# Altium schematic sheet ГРЛМ.468389.005 Э3.SchDoc
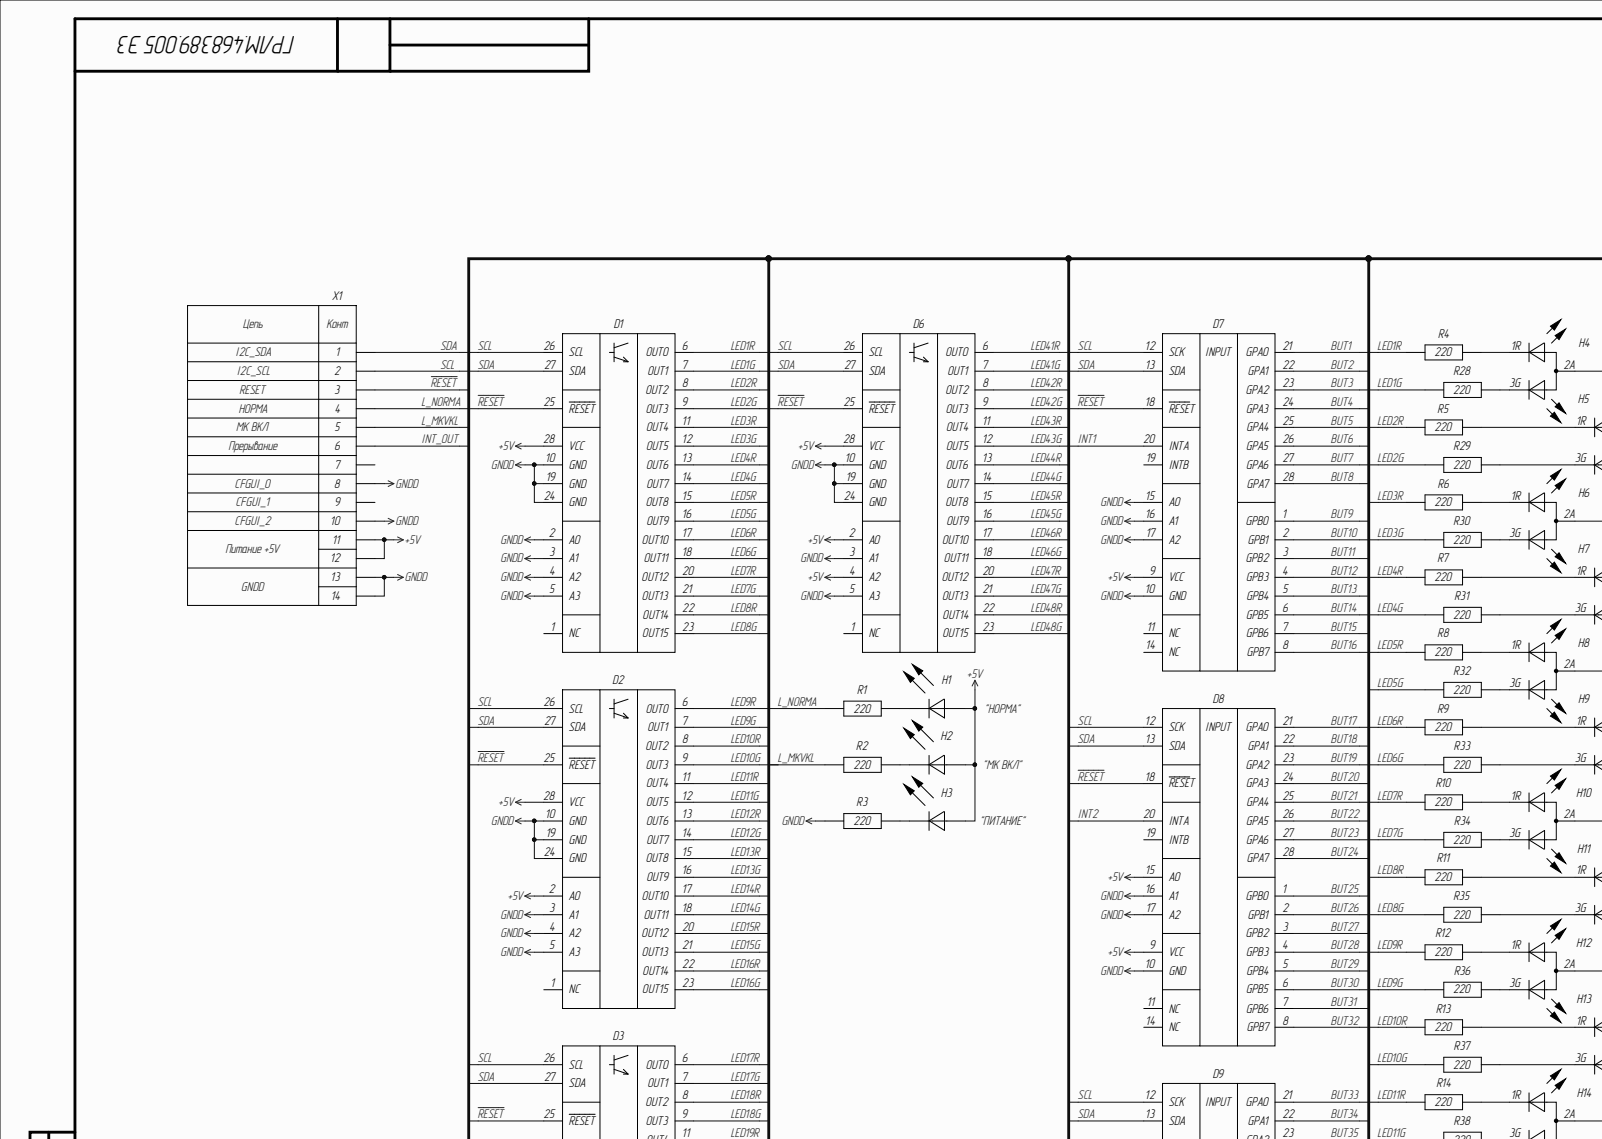
[diagram p0: ГРЛМ.468389.005 Э3.SchDoc, top left]
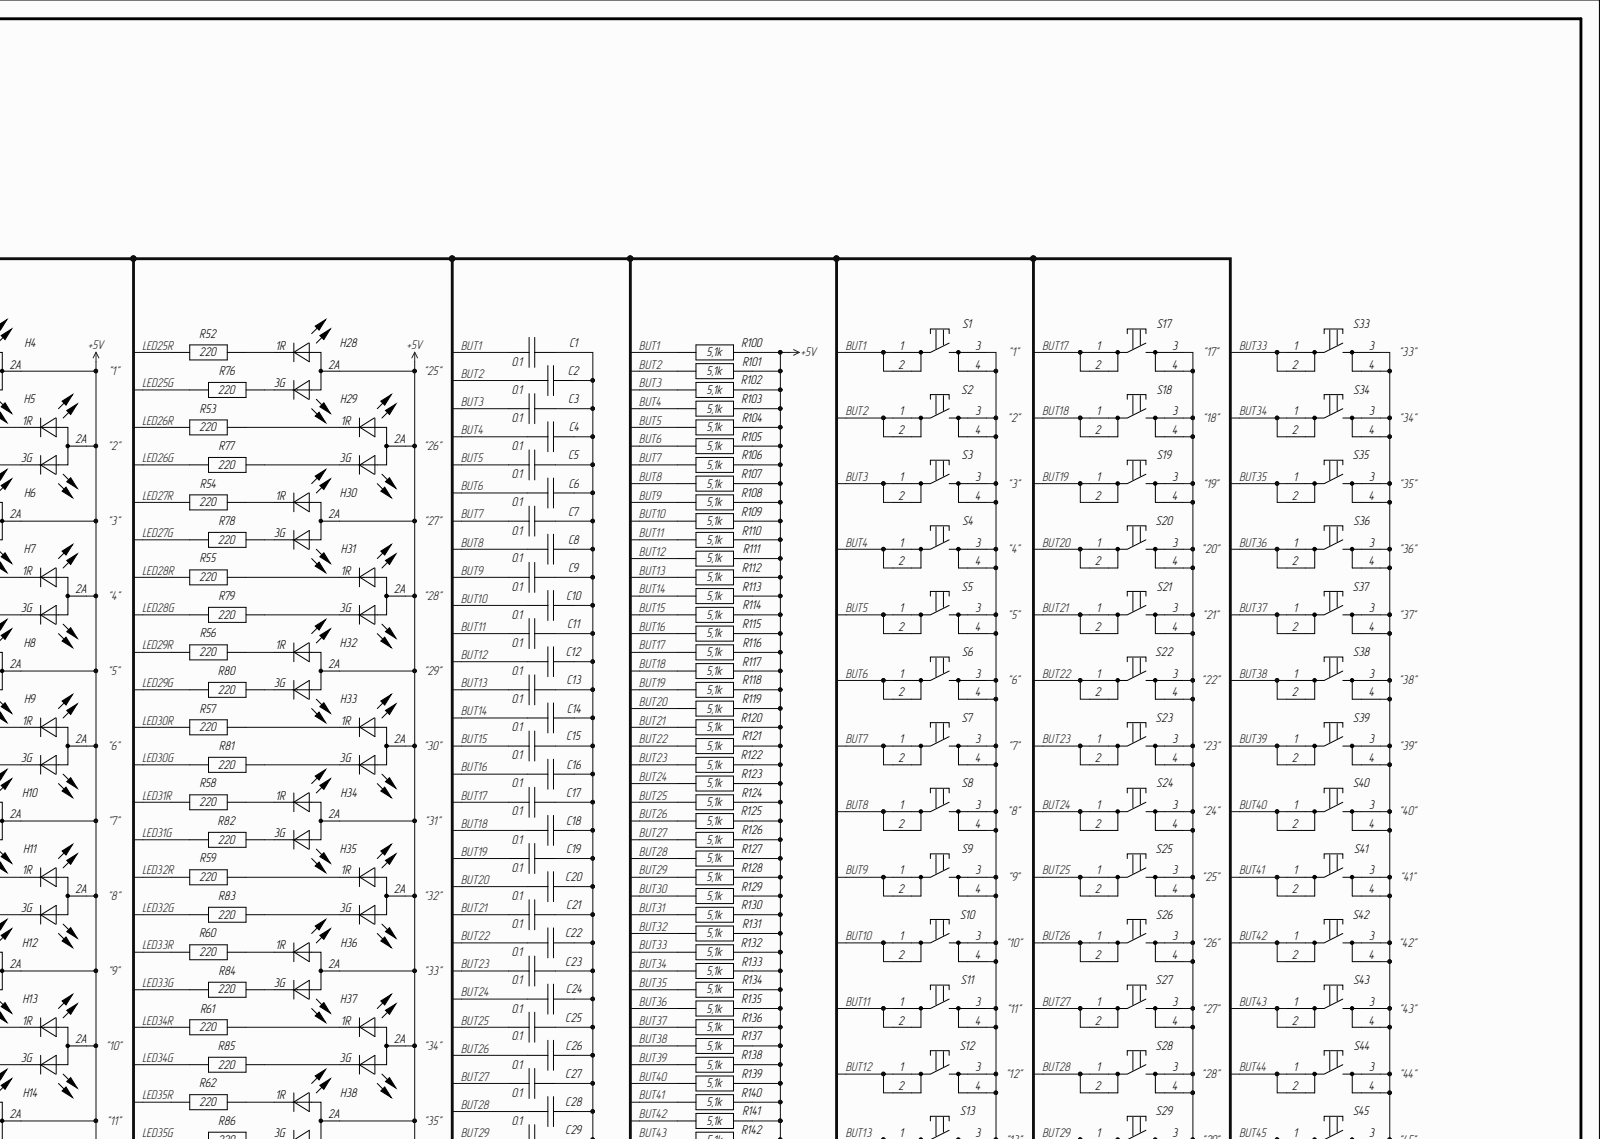
[diagram p1: ГРЛМ.468389.005 Э3.SchDoc, top right]
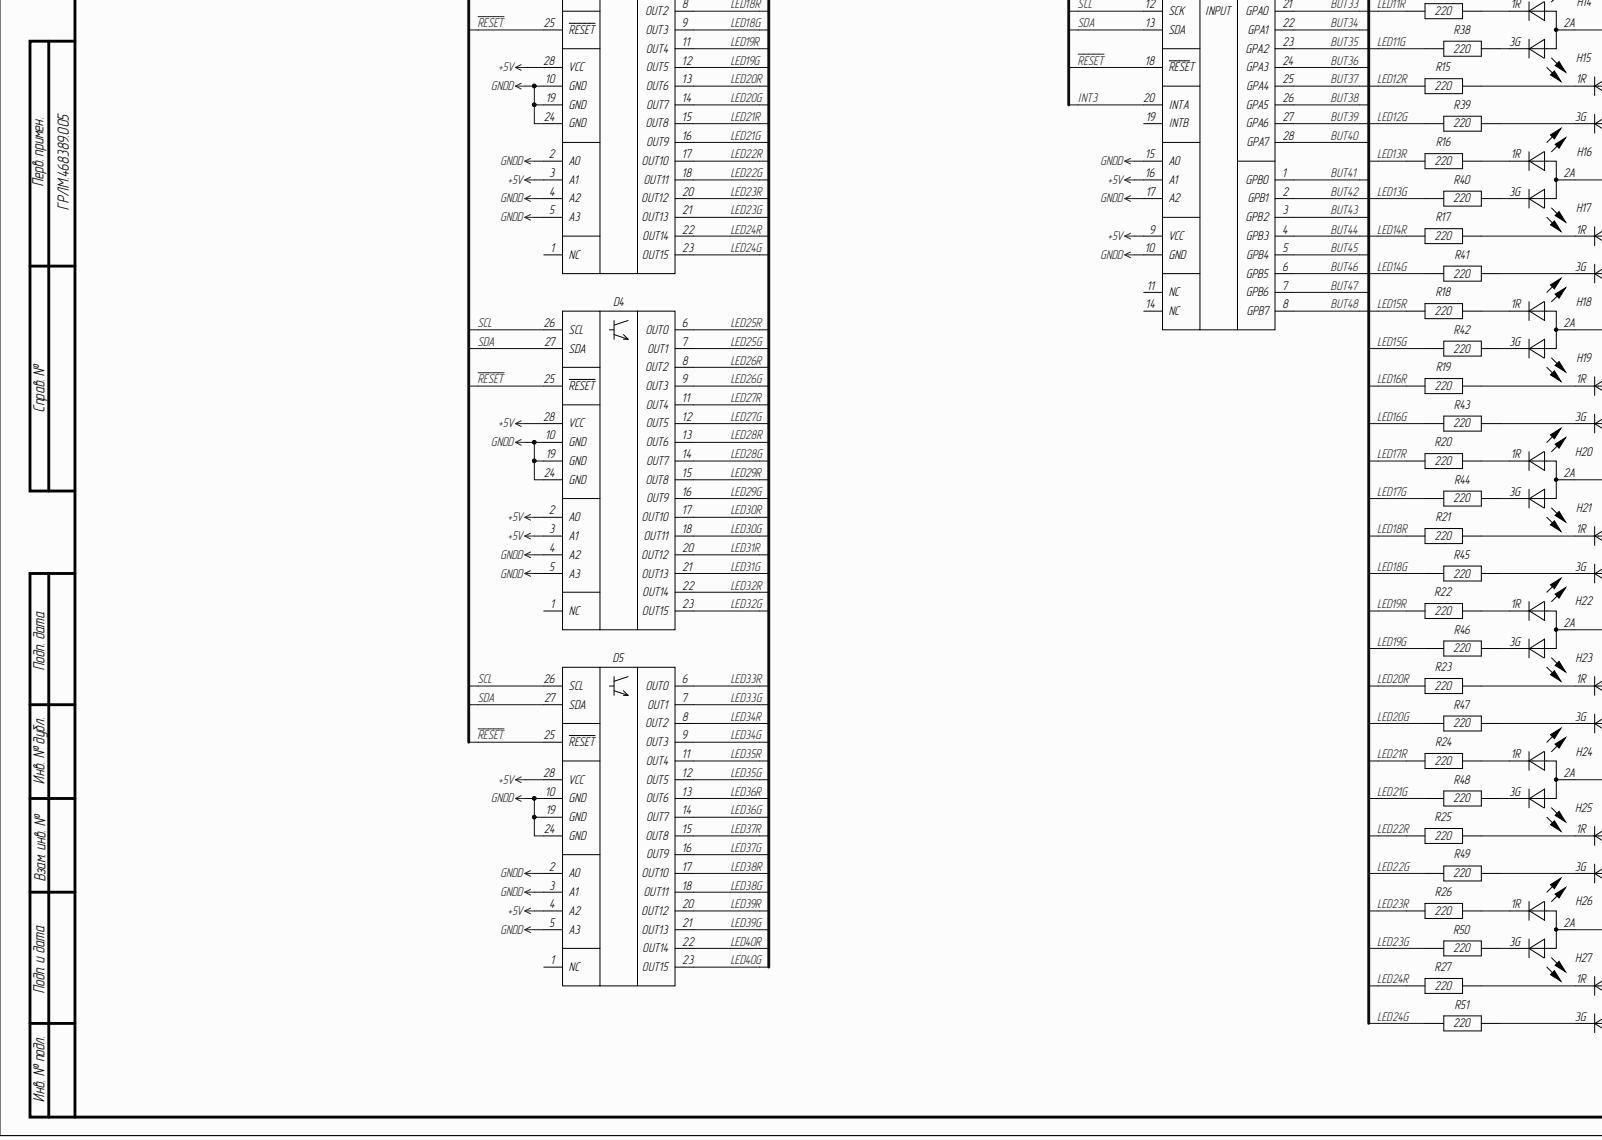
[diagram p2: ГРЛМ.468389.005 Э3.SchDoc, bottom left]
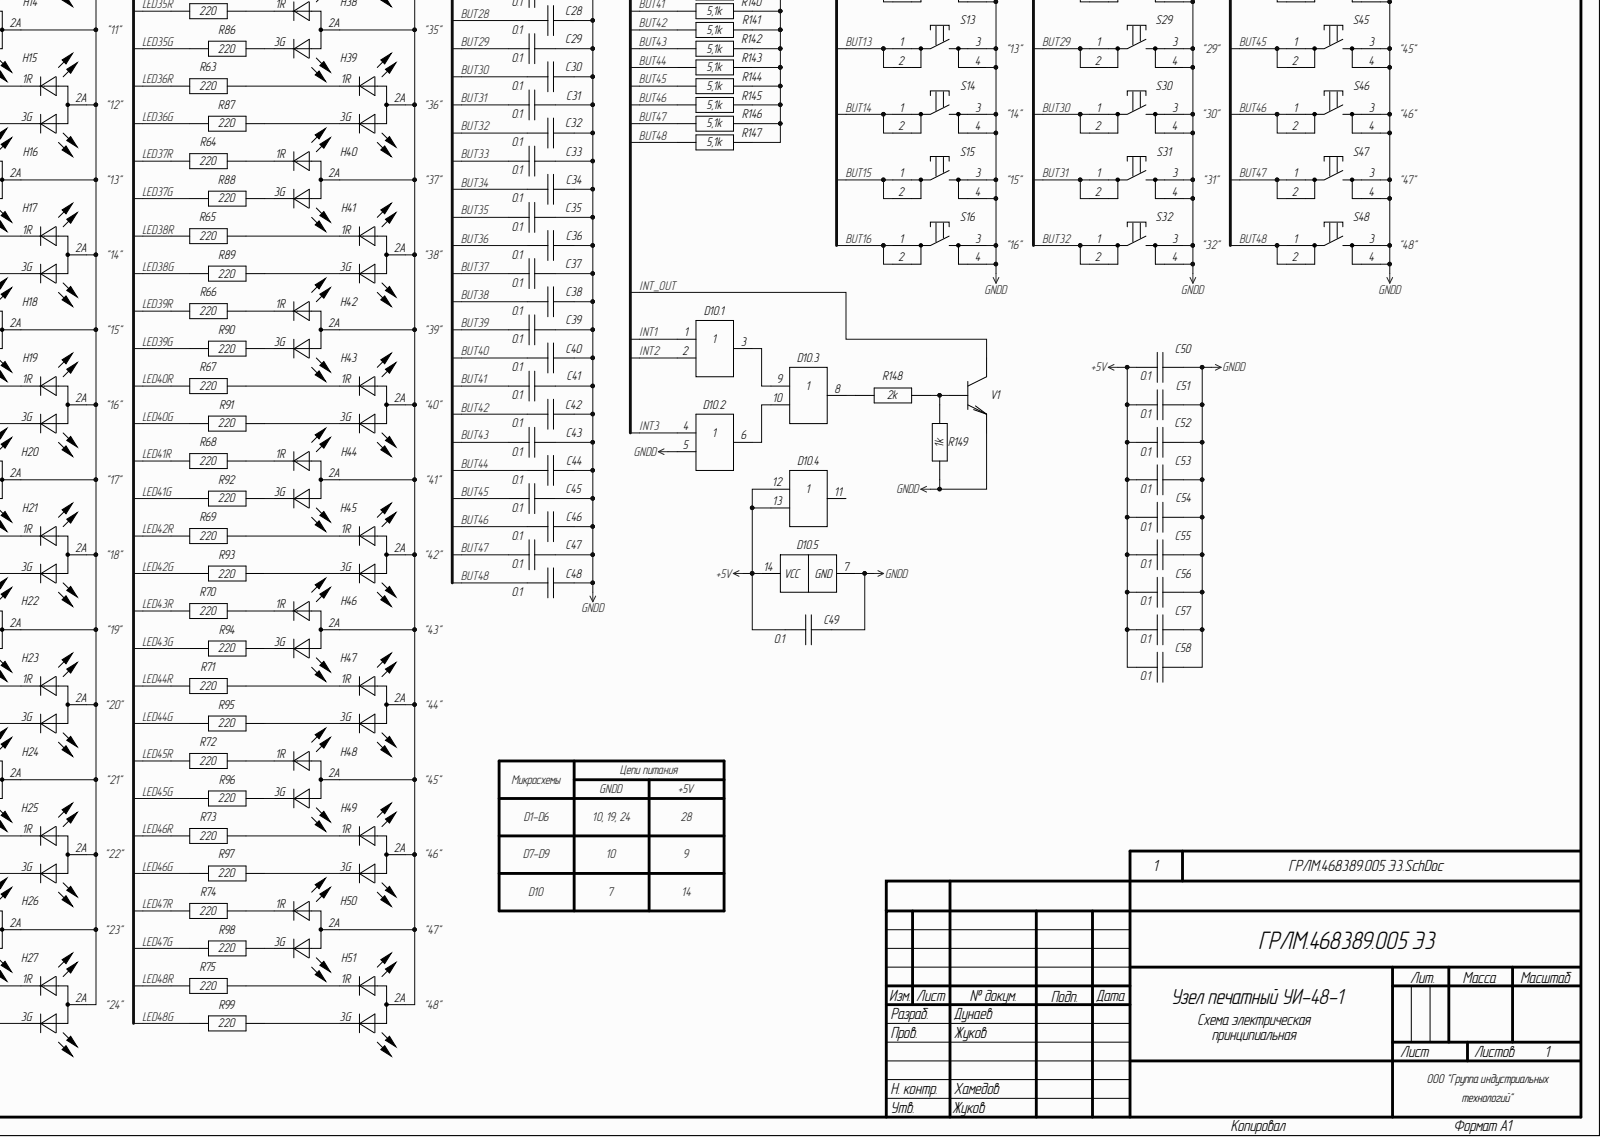
[diagram p3: ГРЛМ.468389.005 Э3.SchDoc, bottom right]

## Parts
C1  value 0.1  [CAP0805]  (hidden comment: CERCAP_0805)
C2  value 0.1  [CAP0805]  (hidden comment: CERCAP_0805)
C3  value 0.1  [CAP0805]  (hidden comment: CERCAP_0805)
C4  value 0.1  [CAP0805]  (hidden comment: CERCAP_0805)
C5  value 0.1  [CAP0805]  (hidden comment: CERCAP_0805)
C6  value 0.1  [CAP0805]  (hidden comment: CERCAP_0805)
C7  value 0.1  [CAP0805]  (hidden comment: CERCAP_0805)
C8  value 0.1  [CAP0805]  (hidden comment: CERCAP_0805)
C9  value 0.1  [CAP0805]  (hidden comment: CERCAP_0805)
C10  value 0.1  [CAP0805]  (hidden comment: CERCAP_0805)
C11  value 0.1  [CAP0805]  (hidden comment: CERCAP_0805)
C12  value 0.1  [CAP0805]  (hidden comment: CERCAP_0805)
C13  value 0.1  [CAP0805]  (hidden comment: CERCAP_0805)
C14  value 0.1  [CAP0805]  (hidden comment: CERCAP_0805)
C15  value 0.1  [CAP0805]  (hidden comment: CERCAP_0805)
C16  value 0.1  [CAP0805]  (hidden comment: CERCAP_0805)
C17  value 0.1  [CAP0805]  (hidden comment: CERCAP_0805)
C18  value 0.1  [CAP0805]  (hidden comment: CERCAP_0805)
C19  value 0.1  [CAP0805]  (hidden comment: CERCAP_0805)
C20  CERCAP_0805  [CAP0805]  (value not drawn)
C21  value 0.1  [CAP0805]  (hidden comment: CERCAP_0805)
C22  value 0.1  [CAP0805]  (hidden comment: CERCAP_0805)
C23  value 0.1  [CAP0805]  (hidden comment: CERCAP_0805)
C24  value 0.1  [CAP0805]  (hidden comment: CERCAP_0805)
C25  value 0.1  [CAP0805]  (hidden comment: CERCAP_0805)
C26  value 0.1  [CAP0805]  (hidden comment: CERCAP_0805)
C27  value 0.1  [CAP0805]  (hidden comment: CERCAP_0805)
C28  value 0.1  [CAP0805]  (hidden comment: CERCAP_0805)
C29  value 0.1  [CAP0805]  (hidden comment: CERCAP_0805)
C30  value 0.1  [CAP0805]  (hidden comment: CERCAP_0805)
C31  value 0.1  [CAP0805]  (hidden comment: CERCAP_0805)
C32  value 0.1  [CAP0805]  (hidden comment: CERCAP_0805)
C33  value 0.1  [CAP0805]  (hidden comment: CERCAP_0805)
C34  value 0.1  [CAP0805]  (hidden comment: CERCAP_0805)
C35  value 0.1  [CAP0805]  (hidden comment: CERCAP_0805)
C36  value 0.1  [CAP0805]  (hidden comment: CERCAP_0805)
C37  value 0.1  [CAP0805]  (hidden comment: CERCAP_0805)
C38  value 0.1  [CAP0805]  (hidden comment: CERCAP_0805)
C39  value 0.1  [CAP0805]  (hidden comment: CERCAP_0805)
C40  value 0.1  [CAP0805]  (hidden comment: CERCAP_0805)
C41  value 0.1  [CAP0805]  (hidden comment: CERCAP_0805)
C42  value 0.1  [CAP0805]  (hidden comment: CERCAP_0805)
C43  value 0.1  [CAP0805]  (hidden comment: CERCAP_0805)
C44  value 0.1  [CAP0805]  (hidden comment: CERCAP_0805)
C45  value 0.1  [CAP0805]  (hidden comment: CERCAP_0805)
C46  value 0.1  [CAP0805]  (hidden comment: CERCAP_0805)
C47  value 0.1  [CAP0805]  (hidden comment: CERCAP_0805)
C48  value 0.1  [CAP0805]  (hidden comment: CERCAP_0805)
C49  value 0.1  [CAP0805]  (hidden comment: CERCAP_0805)
C50  value 0.1  [CAP0805]  (hidden comment: CERCAP_0805)
C51  value 0.1  [CAP0805]  (hidden comment: CERCAP_0805)
C52  value 0.1  [CAP0805]  (hidden comment: CERCAP_0805)
C53  value 0.1  [CAP0805]  (hidden comment: CERCAP_0805)
C54  value 0.1  [CAP0805]  (hidden comment: CERCAP_0805)
C55  value 0.1  [CAP0805]  (hidden comment: CERCAP_0805)
C56  value 0.1  [CAP0805]  (hidden comment: CERCAP_0805)
C57  value 0.1  [CAP0805]  (hidden comment: CERCAP_0805)
C58  value 0.1  [CAP0805]  (hidden comment: CERCAP_0805)
D1  TLC59116F  [TLC59116F]  (value not drawn)
D2  TLC59116F  [TLC59116F]  (value not drawn)
D3  TLC59116F  [TLC59116F]  (value not drawn)
D4  TLC59116F  [TLC59116F]  (value not drawn)
D5  TLC59116F  [TLC59116F]  (value not drawn)
D6  TLC59116F  [TLC59116F]  (value not drawn)
D7  MCP23017  [MCP23017]  (value not drawn)
D8  MCP23017  [MCP23017]  (value not drawn)
D9  MCP23017  [MCP23017]  (value not drawn)
D10  ЭКФ1533ЛЛ1  [ЭКФ1533ЛЛ1]  (value not drawn)  (parts .1,.2,.3,.4,.5)
H1  TLHG4400  [TLHG4400]  (value not drawn)
H2  TLHR4400  [TLHR4400]  (value not drawn)
H3  TLHG4400  [TLHG4400]  (value not drawn)
H4  SSL-LX3059IGW-CA  [SSL-LX3059IGW-CA]  (value not drawn)
H5  SSL-LX3059IGW-CA  [SSL-LX3059IGW-CA]  (value not drawn)
H6  SSL-LX3059IGW-CA  [SSL-LX3059IGW-CA]  (value not drawn)
H7  SSL-LX3059IGW-CA  [SSL-LX3059IGW-CA]  (value not drawn)
H8  SSL-LX3059IGW-CA  [SSL-LX3059IGW-CA]  (value not drawn)
H9  SSL-LX3059IGW-CA  [SSL-LX3059IGW-CA]  (value not drawn)
H10  SSL-LX3059IGW-CA  [SSL-LX3059IGW-CA]  (value not drawn)
H11  SSL-LX3059IGW-CA  [SSL-LX3059IGW-CA]  (value not drawn)
H12  SSL-LX3059IGW-CA  [SSL-LX3059IGW-CA]  (value not drawn)
H13  SSL-LX3059IGW-CA  [SSL-LX3059IGW-CA]  (value not drawn)
H14  SSL-LX3059IGW-CA  [SSL-LX3059IGW-CA]  (value not drawn)
H15  SSL-LX3059IGW-CA  [SSL-LX3059IGW-CA]  (value not drawn)
H16  SSL-LX3059IGW-CA  [SSL-LX3059IGW-CA]  (value not drawn)
H17  SSL-LX3059IGW-CA  [SSL-LX3059IGW-CA]  (value not drawn)
H18  SSL-LX3059IGW-CA  [SSL-LX3059IGW-CA]  (value not drawn)
H19  SSL-LX3059IGW-CA  [SSL-LX3059IGW-CA]  (value not drawn)
H20  SSL-LX3059IGW-CA  [SSL-LX3059IGW-CA]  (value not drawn)
H21  SSL-LX3059IGW-CA  [SSL-LX3059IGW-CA]  (value not drawn)
H22  SSL-LX3059IGW-CA  [SSL-LX3059IGW-CA]  (value not drawn)
H23  SSL-LX3059IGW-CA  [SSL-LX3059IGW-CA]  (value not drawn)
H24  SSL-LX3059IGW-CA  [SSL-LX3059IGW-CA]  (value not drawn)
H25  SSL-LX3059IGW-CA  [SSL-LX3059IGW-CA]  (value not drawn)
H26  SSL-LX3059IGW-CA  [SSL-LX3059IGW-CA]  (value not drawn)
H27  SSL-LX3059IGW-CA  [SSL-LX3059IGW-CA]  (value not drawn)
H28  SSL-LX3059IGW-CA  [SSL-LX3059IGW-CA]  (value not drawn)
H29  SSL-LX3059IGW-CA  [SSL-LX3059IGW-CA]  (value not drawn)
H30  SSL-LX3059IGW-CA  [SSL-LX3059IGW-CA]  (value not drawn)
H31  SSL-LX3059IGW-CA  [SSL-LX3059IGW-CA]  (value not drawn)
H32  SSL-LX3059IGW-CA  [SSL-LX3059IGW-CA]  (value not drawn)
H33  SSL-LX3059IGW-CA  [SSL-LX3059IGW-CA]  (value not drawn)
H34  SSL-LX3059IGW-CA  [SSL-LX3059IGW-CA]  (value not drawn)
H35  SSL-LX3059IGW-CA  [SSL-LX3059IGW-CA]  (value not drawn)
H36  SSL-LX3059IGW-CA  [SSL-LX3059IGW-CA]  (value not drawn)
H37  SSL-LX3059IGW-CA  [SSL-LX3059IGW-CA]  (value not drawn)
H38  SSL-LX3059IGW-CA  [SSL-LX3059IGW-CA]  (value not drawn)
H39  SSL-LX3059IGW-CA  [SSL-LX3059IGW-CA]  (value not drawn)
H40  SSL-LX3059IGW-CA  [SSL-LX3059IGW-CA]  (value not drawn)
H41  SSL-LX3059IGW-CA  [SSL-LX3059IGW-CA]  (value not drawn)
H42  SSL-LX3059IGW-CA  [SSL-LX3059IGW-CA]  (value not drawn)
H43  SSL-LX3059IGW-CA  [SSL-LX3059IGW-CA]  (value not drawn)
H44  SSL-LX3059IGW-CA  [SSL-LX3059IGW-CA]  (value not drawn)
H45  SSL-LX3059IGW-CA  [SSL-LX3059IGW-CA]  (value not drawn)
H46  SSL-LX3059IGW-CA  [SSL-LX3059IGW-CA]  (value not drawn)
H47  SSL-LX3059IGW-CA  [SSL-LX3059IGW-CA]  (value not drawn)
H48  SSL-LX3059IGW-CA  [SSL-LX3059IGW-CA]  (value not drawn)
H49  SSL-LX3059IGW-CA  [SSL-LX3059IGW-CA]  (value not drawn)
H50  SSL-LX3059IGW-CA  [SSL-LX3059IGW-CA]  (value not drawn)
H51  SSL-LX3059IGW-CA  [SSL-LX3059IGW-CA]  (value not drawn)
R1  value 220  [RES0805]  (hidden comment: RES_0805)
R2  value 220  [RES0805]  (hidden comment: RES_0805)
R3  value 220  [RES0805]  (hidden comment: RES_0805)
R4  value 220  [RES0805]  (hidden comment: RES_0805)
R5  value 220  [RES0805]  (hidden comment: RES_0805)
R6  value 220  [RES0805]  (hidden comment: RES_0805)
R7  value 220  [RES0805]  (hidden comment: RES_0805)
R8  value 220  [RES0805]  (hidden comment: RES_0805)
R9  value 220  [RES0805]  (hidden comment: RES_0805)
R10  value 220  [RES0805]  (hidden comment: RES_0805)
R11  value 220  [RES0805]  (hidden comment: RES_0805)
R12  value 220  [RES0805]  (hidden comment: RES_0805)
R13  value 220  [RES0805]  (hidden comment: RES_0805)
R14  value 220  [RES0805]  (hidden comment: RES_0805)
R15  value 220  [RES0805]  (hidden comment: RES_0805)
R16  value 220  [RES0805]  (hidden comment: RES_0805)
R17  value 220  [RES0805]  (hidden comment: RES_0805)
R18  value 220  [RES0805]  (hidden comment: RES_0805)
R19  value 220  [RES0805]  (hidden comment: RES_0805)
R20  value 220  [RES0805]  (hidden comment: RES_0805)
R21  value 220  [RES0805]  (hidden comment: RES_0805)
R22  value 220  [RES0805]  (hidden comment: RES_0805)
R23  value 220  [RES0805]  (hidden comment: RES_0805)
R24  value 220  [RES0805]  (hidden comment: RES_0805)
R25  value 220  [RES0805]  (hidden comment: RES_0805)
R26  value 220  [RES0805]  (hidden comment: RES_0805)
R27  value 220  [RES0805]  (hidden comment: RES_0805)
R28  value 220  [RES0805]  (hidden comment: RES_0805)
R29  value 220  [RES0805]  (hidden comment: RES_0805)
R30  value 220  [RES0805]  (hidden comment: RES_0805)
R31  value 220  [RES0805]  (hidden comment: RES_0805)
R32  value 220  [RES0805]  (hidden comment: RES_0805)
R33  value 220  [RES0805]  (hidden comment: RES_0805)
R34  value 220  [RES0805]  (hidden comment: RES_0805)
R35  value 220  [RES0805]  (hidden comment: RES_0805)
R36  value 220  [RES0805]  (hidden comment: RES_0805)
R37  value 220  [RES0805]  (hidden comment: RES_0805)
R38  value 220  [RES0805]  (hidden comment: RES_0805)
R39  value 220  [RES0805]  (hidden comment: RES_0805)
R40  value 220  [RES0805]  (hidden comment: RES_0805)
R41  value 220  [RES0805]  (hidden comment: RES_0805)
R42  value 220  [RES0805]  (hidden comment: RES_0805)
R43  value 220  [RES0805]  (hidden comment: RES_0805)
R44  value 220  [RES0805]  (hidden comment: RES_0805)
R45  value 220  [RES0805]  (hidden comment: RES_0805)
R46  value 220  [RES0805]  (hidden comment: RES_0805)
R47  value 220  [RES0805]  (hidden comment: RES_0805)
R48  value 220  [RES0805]  (hidden comment: RES_0805)
R49  value 220  [RES0805]  (hidden comment: RES_0805)
R50  value 220  [RES0805]  (hidden comment: RES_0805)
R51  value 220  [RES0805]  (hidden comment: RES_0805)
R52  value 220  [RES0805]  (hidden comment: RES_0805)
R53  value 220  [RES0805]  (hidden comment: RES_0805)
R54  value 220  [RES0805]  (hidden comment: RES_0805)
R55  value 220  [RES0805]  (hidden comment: RES_0805)
R56  value 220  [RES0805]  (hidden comment: RES_0805)
R57  value 220  [RES0805]  (hidden comment: RES_0805)
R58  value 220  [RES0805]  (hidden comment: RES_0805)
R59  value 220  [RES0805]  (hidden comment: RES_0805)
R60  value 220  [RES0805]  (hidden comment: RES_0805)
R61  value 220  [RES0805]  (hidden comment: RES_0805)
R62  value 220  [RES0805]  (hidden comment: RES_0805)
R63  value 220  [RES0805]  (hidden comment: RES_0805)
R64  value 220  [RES0805]  (hidden comment: RES_0805)
R65  value 220  [RES0805]  (hidden comment: RES_0805)
R66  value 220  [RES0805]  (hidden comment: RES_0805)
R67  value 220  [RES0805]  (hidden comment: RES_0805)
R68  value 220  [RES0805]  (hidden comment: RES_0805)
R69  value 220  [RES0805]  (hidden comment: RES_0805)
R70  value 220  [RES0805]  (hidden comment: RES_0805)
R71  value 220  [RES0805]  (hidden comment: RES_0805)
R72  value 220  [RES0805]  (hidden comment: RES_0805)
R73  value 220  [RES0805]  (hidden comment: RES_0805)
R74  value 220  [RES0805]  (hidden comment: RES_0805)
R75  value 220  [RES0805]  (hidden comment: RES_0805)
R76  value 220  [RES0805]  (hidden comment: RES_0805)
R77  value 220  [RES0805]  (hidden comment: RES_0805)
R78  value 220  [RES0805]  (hidden comment: RES_0805)
R79  value 220  [RES0805]  (hidden comment: RES_0805)
R80  value 220  [RES0805]  (hidden comment: RES_0805)
R81  value 220  [RES0805]  (hidden comment: RES_0805)
R82  value 220  [RES0805]  (hidden comment: RES_0805)
R83  value 220  [RES0805]  (hidden comment: RES_0805)
R84  value 220  [RES0805]  (hidden comment: RES_0805)
R85  value 220  [RES0805]  (hidden comment: RES_0805)
R86  value 220  [RES0805]  (hidden comment: RES_0805)
R87  value 220  [RES0805]  (hidden comment: RES_0805)
R88  value 220  [RES0805]  (hidden comment: RES_0805)
R89  value 220  [RES0805]  (hidden comment: RES_0805)
R90  value 220  [RES0805]  (hidden comment: RES_0805)
R91  value 220  [RES0805]  (hidden comment: RES_0805)
R92  value 220  [RES0805]  (hidden comment: RES_0805)
R93  value 220  [RES0805]  (hidden comment: RES_0805)
R94  value 220  [RES0805]  (hidden comment: RES_0805)
R95  value 220  [RES0805]  (hidden comment: RES_0805)
R96  value 220  [RES0805]  (hidden comment: RES_0805)
R97  value 220  [RES0805]  (hidden comment: RES_0805)
R98  value 220  [RES0805]  (hidden comment: RES_0805)
R99  value 220  [RES0805]  (hidden comment: RES_0805)
R100  value 5,1k  [RES0805]  (hidden comment: RES_0805)
R101  value 5,1k  [RES0805]  (hidden comment: RES_0805)
R102  value 5,1k  [RES0805]  (hidden comment: RES_0805)
R103  value 5,1k  [RES0805]  (hidden comment: RES_0805)
R104  value 5,1k  [RES0805]  (hidden comment: RES_0805)
R105  value 5,1k  [RES0805]  (hidden comment: RES_0805)
R106  value 5,1k  [RES0805]  (hidden comment: RES_0805)
R107  value 5,1k  [RES0805]  (hidden comment: RES_0805)
R108  value 5,1k  [RES0805]  (hidden comment: RES_0805)
R109  value 5,1k  [RES0805]  (hidden comment: RES_0805)
R110  value 5,1k  [RES0805]  (hidden comment: RES_0805)
R111  value 5,1k  [RES0805]  (hidden comment: RES_0805)
R112  value 5,1k  [RES0805]  (hidden comment: RES_0805)
R113  value 5,1k  [RES0805]  (hidden comment: RES_0805)
R114  value 5,1k  [RES0805]  (hidden comment: RES_0805)
R115  value 5,1k  [RES0805]  (hidden comment: RES_0805)
R116  value 5,1k  [RES0805]  (hidden comment: RES_0805)
R117  value 5,1k  [RES0805]  (hidden comment: RES_0805)
R118  value 5,1k  [RES0805]  (hidden comment: RES_0805)
R119  value 5,1k  [RES0805]  (hidden comment: RES_0805)
R120  value 5,1k  [RES0805]  (hidden comment: RES_0805)
R121  value 5,1k  [RES0805]  (hidden comment: RES_0805)
R122  value 5,1k  [RES0805]  (hidden comment: RES_0805)
R123  value 5,1k  [RES0805]  (hidden comment: RES_0805)
R124  value 5,1k  [RES0805]  (hidden comment: RES_0805)
R125  value 5,1k  [RES0805]  (hidden comment: RES_0805)
R126  value 5,1k  [RES0805]  (hidden comment: RES_0805)
R127  value 5,1k  [RES0805]  (hidden comment: RES_0805)
R128  value 5,1k  [RES0805]  (hidden comment: RES_0805)
R129  value 5,1k  [RES0805]  (hidden comment: RES_0805)
R130  value 5,1k  [RES0805]  (hidden comment: RES_0805)
R131  value 5,1k  [RES0805]  (hidden comment: RES_0805)
R132  value 5,1k  [RES0805]  (hidden comment: RES_0805)
R133  value 5,1k  [RES0805]  (hidden comment: RES_0805)
R134  value 5,1k  [RES0805]  (hidden comment: RES_0805)
R135  value 5,1k  [RES0805]  (hidden comment: RES_0805)
R136  value 5,1k  [RES0805]  (hidden comment: RES_0805)
R137  value 5,1k  [RES0805]  (hidden comment: RES_0805)
R138  value 5,1k  [RES0805]  (hidden comment: RES_0805)
R139  value 5,1k  [RES0805]  (hidden comment: RES_0805)
R140  value 5,1k  [RES0805]  (hidden comment: RES_0805)
R141  value 5,1k  [RES0805]  (hidden comment: RES_0805)
R142  value 5,1k  [RES0805]  (hidden comment: RES_0805)
R143  value 5,1k  [RES0805]  (hidden comment: RES_0805)
R144  value 5,1k  [RES0805]  (hidden comment: RES_0805)
R145  value 5,1k  [RES0805]  (hidden comment: RES_0805)
R146  value 5,1k  [RES0805]  (hidden comment: RES_0805)
R147  value 5,1k  [RES0805]  (hidden comment: RES_0805)
R148  value 2k  [RES0805]  (hidden comment: RES_0805)
R149  value 1k  [RES0805]  (hidden comment: RES_0805)
S1  1273SHIM-160G-G  [1273SHIM-160G-G с толкателем]  (value not drawn)
S2  1273SHIM-160G-G  [1273SHIM-160G-G с толкателем]  (value not drawn)
S3  1273SHIM-160G-G  [1273SHIM-160G-G с толкателем]  (value not drawn)
S4  1273SHIM-160G-G  [1273SHIM-160G-G с толкателем]  (value not drawn)
S5  1273SHIM-160G-G  [1273SHIM-160G-G с толкателем]  (value not drawn)
S6  1273SHIM-160G-G  [1273SHIM-160G-G с толкателем]  (value not drawn)
S7  1273SHIM-160G-G  [1273SHIM-160G-G с толкателем]  (value not drawn)
S8  1273SHIM-160G-G  [1273SHIM-160G-G с толкателем]  (value not drawn)
S9  1273SHIM-160G-G  [1273SHIM-160G-G с толкателем]  (value not drawn)
S10  1273SHIM-160G-G  [1273SHIM-160G-G с толкателем]  (value not drawn)
S11  1273SHIM-160G-G  [1273SHIM-160G-G с толкателем]  (value not drawn)
S12  1273SHIM-160G-G  [1273SHIM-160G-G с толкателем]  (value not drawn)
S13  1273SHIM-160G-G  [1273SHIM-160G-G с толкателем]  (value not drawn)
S14  1273SHIM-160G-G  [1273SHIM-160G-G с толкателем]  (value not drawn)
S15  1273SHIM-160G-G  [1273SHIM-160G-G с толкателем]  (value not drawn)
S16  1273SHIM-160G-G  [1273SHIM-160G-G с толкателем]  (value not drawn)
S17  1273SHIM-160G-G  [1273SHIM-160G-G с толкателем]  (value not drawn)
S18  1273SHIM-160G-G  [1273SHIM-160G-G с толкателем]  (value not drawn)
S19  1273SHIM-160G-G  [1273SHIM-160G-G с толкателем]  (value not drawn)
S20  1273SHIM-160G-G  [1273SHIM-160G-G с толкателем]  (value not drawn)
S21  1273SHIM-160G-G  [1273SHIM-160G-G с толкателем]  (value not drawn)
S22  1273SHIM-160G-G  [1273SHIM-160G-G с толкателем]  (value not drawn)
S23  1273SHIM-160G-G  [1273SHIM-160G-G с толкателем]  (value not drawn)
S24  1273SHIM-160G-G  [1273SHIM-160G-G с толкателем]  (value not drawn)
S25  1273SHIM-160G-G  [1273SHIM-160G-G с толкателем]  (value not drawn)
S26  1273SHIM-160G-G  [1273SHIM-160G-G с толкателем]  (value not drawn)
S27  1273SHIM-160G-G  [1273SHIM-160G-G с толкателем]  (value not drawn)
S28  1273SHIM-160G-G  [1273SHIM-160G-G с толкателем]  (value not drawn)
S29  1273SHIM-160G-G  [1273SHIM-160G-G с толкателем]  (value not drawn)
S30  1273SHIM-160G-G  [1273SHIM-160G-G с толкателем]  (value not drawn)
S31  1273SHIM-160G-G  [1273SHIM-160G-G с толкателем]  (value not drawn)
S32  1273SHIM-160G-G  [1273SHIM-160G-G с толкателем]  (value not drawn)
S33  1273SHIM-160G-G  [1273SHIM-160G-G с толкателем]  (value not drawn)
S34  1273SHIM-160G-G  [1273SHIM-160G-G с толкателем]  (value not drawn)
S35  1273SHIM-160G-G  [1273SHIM-160G-G с толкателем]  (value not drawn)
S36  1273SHIM-160G-G  [1273SHIM-160G-G с толкателем]  (value not drawn)
S37  1273SHIM-160G-G  [1273SHIM-160G-G с толкателем]  (value not drawn)
S38  1273SHIM-160G-G  [1273SHIM-160G-G с толкателем]  (value not drawn)
S39  1273SHIM-160G-G  [1273SHIM-160G-G с толкателем]  (value not drawn)
S40  1273SHIM-160G-G  [1273SHIM-160G-G с толкателем]  (value not drawn)
S41  1273SHIM-160G-G  [1273SHIM-160G-G с толкателем]  (value not drawn)
S42  1273SHIM-160G-G  [1273SHIM-160G-G с толкателем]  (value not drawn)
S43  1273SHIM-160G-G  [1273SHIM-160G-G с толкателем]  (value not drawn)
S44  1273SHIM-160G-G  [1273SHIM-160G-G с толкателем]  (value not drawn)
S45  1273SHIM-160G-G  [1273SHIM-160G-G с толкателем]  (value not drawn)
S46  1273SHIM-160G-G  [1273SHIM-160G-G с толкателем]  (value not drawn)
S47  1273SHIM-160G-G  [1273SHIM-160G-G с толкателем]  (value not drawn)
S48  1273SHIM-160G-G  [1273SHIM-160G-G с толкателем]  (value not drawn)
V1  КТ229Г9  [КТ229Г9]  (value not drawn)
X1  IDC-14MS  [BH-14]  (value not drawn)

## Nets
+5V: C49.2 C50.2 C51.2 C52.2 C53.2 C54.2 C55.2 C56.2 C57.2 C58.2 D1.28(VCC) D2.2(A0) D2.28(VCC) D3.3(A1) D3.28(VCC) D4.2(A0) D4.3(A1) D4.28(VCC) D5.4(A2) D5.28(VCC) D6.2(A0) D6.4(A2) D6.28(VCC) D7.9(VCC) D8.9(VCC) D8.15(A0) D9.9(VCC) D9.16(A1) D10.12 D10.13 D10.14(VCC) H1.2 H2.2 H3.2 H4.2A H5.2A H6.2A H7.2A H8.2A H9.2A H10.2A H11.2A H12.2A H13.2A H14.2A H15.2A H16.2A H17.2A H18.2A H19.2A H20.2A H21.2A H22.2A H23.2A H24.2A H25.2A H26.2A H27.2A H28.2A H29.2A H30.2A H31.2A H32.2A H33.2A H34.2A H35.2A H36.2A H37.2A H38.2A H39.2A H40.2A H41.2A H42.2A H43.2A H44.2A H45.2A H46.2A H47.2A H48.2A H49.2A H50.2A H51.2A R100.2 R101.2 R102.2 R103.2 R104.2 R105.2 R106.2 R107.2 R108.2 R109.2 R110.2 R111.2 R112.2 R113.2 R114.2 R115.2 R116.2 R117.2 R118.2 R119.2 R120.2 R121.2 R122.2 R123.2 R124.2 R125.2 R126.2 R127.2 R128.2 R129.2 R130.2 R131.2 R132.2 R133.2 R134.2 R135.2 R136.2 R137.2 R138.2 R139.2 R140.2 R141.2 R142.2 R143.2 R144.2 R145.2 R146.2 R147.2 X1.11 X1.12
GNDD: C1.1 C2.1 C3.1 C4.1 C5.1 C6.1 C7.1 C8.1 C9.1 C10.1 C11.1 C12.1 C13.1 C14.1 C15.1 C16.1 C17.1 C18.1 C19.1 C20.1 C21.1 C22.1 C23.1 C24.1 C25.1 C26.1 C27.1 C28.1 C29.1 C30.1 C31.1 C32.1 C33.1 C34.1 C35.1 C36.1 C37.1 C38.1 C39.1 C40.1 C41.1 C42.1 C43.1 C44.1 C45.1 C46.1 C47.1 C48.1 C49.1 C50.1 C51.1 C52.1 C53.1 C54.1 C55.1 C56.1 C57.1 C58.1 D1.2(A0) D1.3(A1) D1.4(A2) D1.5(A3) D1.10(GND) D1.19(GND) D1.24(GND) D2.3(A1) D2.4(A2) D2.5(A3) D2.10(GND) D2.19(GND) D2.24(GND) D3.2(A0) D3.4(A2) D3.5(A3) D3.10(GND) D3.19(GND) D3.24(GND) D4.4(A2) D4.5(A3) D4.10(GND) D4.19(GND) D4.24(GND) D5.2(A0) D5.3(A1) D5.5(A3) D5.10(GND) D5.19(GND) D5.24(GND) D6.3(A1) D6.5(A3) D6.10(GND) D6.19(GND) D6.24(GND) D7.10(GND) D7.15(A0) D7.16(A1) D7.17(A2) D8.10(GND) D8.16(A1) D8.17(A2) D9.10(GND) D9.15(A0) D9.17(A2) D10.5 D10.7(GND) R3.1 R149.1 S1.3 S1.4 S2.3 S2.4 S3.3 S3.4 S4.3 S4.4 S5.3 S5.4 S6.3 S6.4 S7.3 S7.4 S8.3 S8.4 S9.3 S9.4 S10.3 S10.4 S11.3 S11.4 S12.3 S12.4 S13.3 S13.4 S14.3 S14.4 S15.3 S15.4 S16.3 S16.4 S17.3 S17.4 S18.3 S18.4 S19.3 S19.4 S20.3 S20.4 S21.3 S21.4 S22.3 S22.4 S23.3 S23.4 S24.3 S24.4 S25.3 S25.4 S26.3 S26.4 S27.3 S27.4 S28.3 S28.4 S29.3 S29.4 S30.3 S30.4 S31.3 S31.4 S32.3 S32.4 S33.3 S33.4 S34.3 S34.4 S35.3 S35.4 S36.3 S36.4 S37.3 S37.4 S38.3 S38.4 S39.3 S39.4 S40.3 S40.4 S41.3 S41.4 S42.3 S42.4 S43.3 S43.4 S44.3 S44.4 S45.3 S45.4 S46.3 S46.4 S47.3 S47.4 S48.3 S48.4 V1.2 X1.8 X1.10 X1.13 X1.14
BUT1: C1.2 D7.21(GPA0) R100.1 S1.1 S1.2
BUT2: C2.2 D7.22(GPA1) R101.1 S2.1 S2.2
BUT3: C3.2 D7.23(GPA2) R102.1 S3.1 S3.2
BUT4: C4.2 D7.24(GPA3) R103.1 S4.1 S4.2
BUT5: C5.2 D7.25(GPA4) R104.1 S5.1 S5.2
BUT6: C6.2 D7.26(GPA5) R105.1 S6.1 S6.2
BUT7: C7.2 D7.27(GPA6) R106.1 S7.1 S7.2
BUT8: C8.2 D7.28(GPA7) R107.1 S8.1 S8.2
BUT9: C9.2 D7.1(GPB0) R108.1 S9.1 S9.2
BUT10: C10.2 D7.2(GPB1) R109.1 S10.1 S10.2
BUT11: C11.2 D7.3(GPB2) R110.1 S11.1 S11.2
BUT12: C12.2 D7.4(GPB3) R111.1 S12.1 S12.2
BUT13: C13.2 D7.5(GPB4) R112.1 S13.1 S13.2
BUT14: C14.2 D7.6(GPB5) R113.1 S14.1 S14.2
BUT15: C15.2 D7.7(GPB6) R114.1 S15.1 S15.2
BUT16: C16.2 D7.8(GPB7) R115.1 S16.1 S16.2
BUT17: C17.2 D8.21(GPA0) R116.1 S17.1 S17.2
BUT18: C18.2 D8.22(GPA1) R117.1 S18.1 S18.2
BUT19: C19.2 D8.23(GPA2) R118.1 S19.1 S19.2
BUT20: C20.2 D8.24(GPA3) R119.1 S20.1 S20.2
BUT21: C21.2 D8.25(GPA4) R120.1 S21.1 S21.2
BUT22: C22.2 D8.26(GPA5) R121.1 S22.1 S22.2
BUT23: C23.2 D8.27(GPA6) R122.1 S23.1 S23.2
BUT24: C24.2 D8.28(GPA7) R123.1 S24.1 S24.2
BUT25: C25.2 D8.1(GPB0) R124.1 S25.1 S25.2
BUT26: C26.2 D8.2(GPB1) R125.1 S26.1 S26.2
BUT27: C27.2 D8.3(GPB2) R126.1 S27.1 S27.2
BUT28: C28.2 D8.4(GPB3) R127.1 S28.1 S28.2
BUT29: C29.2 D8.5(GPB4) R128.1 S29.1 S29.2
BUT30: C30.2 D8.6(GPB5) R129.1 S30.1 S30.2
BUT31: C31.2 D8.7(GPB6) R130.1 S31.1 S31.2
BUT32: C32.2 D8.8(GPB7) R131.1 S32.1 S32.2
BUT33: C33.2 D9.21(GPA0) R132.1 S33.1 S33.2
BUT34: C34.2 D9.22(GPA1) R133.1 S34.1 S34.2
BUT35: C35.2 D9.23(GPA2) R134.1 S35.1 S35.2
BUT36: C36.2 D9.24(GPA3) R135.1 S36.1 S36.2
BUT37: C37.2 D9.25(GPA4) R136.1 S37.1 S37.2
BUT38: C38.2 D9.26(GPA5) R137.1 S38.1 S38.2
BUT39: C39.2 D9.27(GPA6) R138.1 S39.1 S39.2
BUT40: C40.2 D9.28(GPA7) R139.1 S40.1 S40.2
BUT41: C41.2 D9.1(GPB0) R140.1 S41.1 S41.2
BUT42: C42.2 D9.2(GPB1) R141.1 S42.1 S42.2
BUT43: C43.2 D9.3(GPB2) R142.1 S43.1 S43.2
BUT44: C44.2 D9.4(GPB3) R143.1 S44.1 S44.2
BUT45: C45.2 D9.5(GPB4) R144.1 S45.1 S45.2
BUT46: C46.2 D9.6(GPB5) R145.1 S46.1 S46.2
BUT47: C47.2 D9.7(GPB6) R146.1 S47.1 S47.2
BUT48: C48.2 D9.8(GPB7) R147.1 S48.1 S48.2
INT1: D7.20(INTA) D10.1
INT2: D8.20(INTA) D10.2
INT3: D9.20(INTA) D10.4
INT_OUT: V1.3 X1.6
L_MKVKL: R2.1 X1.5
L_NORMA: R1.1 X1.4
LED1G: D1.7(OUT1) R28.1
LED1R: D1.6(OUT0) R4.1
LED2G: D1.9(OUT3) R29.1
LED2R: D1.8(OUT2) R5.1
LED3G: D1.12(OUT5) R30.1
LED3R: D1.11(OUT4) R6.1
LED4G: D1.14(OUT7) R31.1
LED4R: D1.13(OUT6) R7.1
LED5G: D1.16(OUT9) R32.1
LED5R: D1.15(OUT8) R8.1
LED6G: D1.18(OUT11) R33.1
LED6R: D1.17(OUT10) R9.1
LED7G: D1.21(OUT13) R34.1
LED7R: D1.20(OUT12) R10.1
LED8G: D1.23(OUT15) R35.1
LED8R: D1.22(OUT14) R11.1
LED9G: D2.7(OUT1) R36.1
LED9R: D2.6(OUT0) R12.1
LED10G: D2.9(OUT3) R37.1
LED10R: D2.8(OUT2) R13.1
LED11G: D2.12(OUT5) R38.1
LED11R: D2.11(OUT4) R14.1
LED12G: D2.14(OUT7) R39.1
LED12R: D2.13(OUT6) R15.1
LED13G: D2.16(OUT9) R40.1
LED13R: D2.15(OUT8) R16.1
LED14G: D2.18(OUT11) R41.1
LED14R: D2.17(OUT10) R17.1
LED15G: D2.21(OUT13) R42.1
LED15R: D2.20(OUT12) R18.1
LED16G: D2.23(OUT15) R43.1
LED16R: D2.22(OUT14) R19.1
LED17G: D3.7(OUT1) R44.1
LED17R: D3.6(OUT0) R20.1
LED18G: D3.9(OUT3) R45.1
LED18R: D3.8(OUT2) R21.1
LED19G: D3.12(OUT5) R46.1
LED19R: D3.11(OUT4) R22.1
LED20G: D3.14(OUT7) R47.1
LED20R: D3.13(OUT6) R23.1
LED21G: D3.16(OUT9) R48.1
LED21R: D3.15(OUT8) R24.1
LED22G: D3.18(OUT11) R49.1
LED22R: D3.17(OUT10) R25.1
LED23G: D3.21(OUT13) R50.1
LED23R: D3.20(OUT12) R26.1
LED24G: D3.23(OUT15) R51.1
LED24R: D3.22(OUT14) R27.1
LED25G: D4.7(OUT1) R76.1
LED25R: D4.6(OUT0) R52.1
LED26G: D4.9(OUT3) R77.1
LED26R: D4.8(OUT2) R53.1
LED27G: D4.12(OUT5) R78.1
LED27R: D4.11(OUT4) R54.1
LED28G: D4.14(OUT7) R79.1
LED28R: D4.13(OUT6) R55.1
LED29G: D4.16(OUT9) R80.1
LED29R: D4.15(OUT8) R56.1
LED30G: D4.18(OUT11) R81.1
LED30R: D4.17(OUT10) R57.1
LED31G: D4.21(OUT13) R82.1
LED31R: D4.20(OUT12) R58.1
LED32G: D4.23(OUT15) R83.1
LED32R: D4.22(OUT14) R59.1
LED33G: D5.7(OUT1) R84.1
LED33R: D5.6(OUT0) R60.1
LED34G: D5.9(OUT3) R85.1
LED34R: D5.8(OUT2) R61.1
LED35G: D5.12(OUT5) R86.1
LED35R: D5.11(OUT4) R62.1
LED36G: D5.14(OUT7) R87.1
LED36R: D5.13(OUT6) R63.1
LED37G: D5.16(OUT9) R88.1
LED37R: D5.15(OUT8) R64.1
LED38G: D5.18(OUT11) R89.1
LED38R: D5.17(OUT10) R65.1
LED39G: D5.21(OUT13) R90.1
LED39R: D5.20(OUT12) R66.1
LED40G: D5.23(OUT15) R91.1
LED40R: D5.22(OUT14) R67.1
LED41G: D6.7(OUT1) R92.1
LED41R: D6.6(OUT0) R68.1
LED42G: D6.9(OUT3) R93.1
LED42R: D6.8(OUT2) R69.1
LED43G: D6.12(OUT5) R94.1
LED43R: D6.11(OUT4) R70.1
LED44G: D6.14(OUT7) R95.1
LED44R: D6.13(OUT6) R71.1
LED45G: D6.16(OUT9) R96.1
LED45R: D6.15(OUT8) R72.1
LED46G: D6.18(OUT11) R97.1
LED46R: D6.17(OUT10) R73.1
LED47G: D6.21(OUT13) R98.1
LED47R: D6.20(OUT12) R74.1
LED48G: D6.23(OUT15) R99.1
LED48R: D6.22(OUT14) R75.1
~{RESET}: D1.25(RESET) D2.25(RESET) D3.25(RESET) D4.25(RESET) D5.25(RESET) D6.25(RESET) D7.18(RESET) D8.18(RESET) D9.18(RESET) X1.3
SCL: D1.26(SCL) D2.26(SCL) D3.26(SCL) D4.26(SCL) D5.26(SCL) D6.26(SCL) D7.12(SCK) D8.12(SCK) D9.12(SCK) X1.2
SDA: D1.27(SDA) D2.27(SDA) D3.27(SDA) D4.27(SDA) D5.27(SDA) D6.27(SDA) D7.13(SDA) D8.13(SDA) D9.13(SDA) X1.1
NetD10_3: D10.3 D10.9
NetD10_6: D10.6 D10.10
NetD10_8: D10.8 R148.1
NetH1_1: H1.1 R1.2
NetH2_1: H2.1 R2.2
NetH3_1: H3.1 R3.2
NetH4_1R: H4.1R R4.2
NetH4_3G: H4.3G R28.2
NetH5_1R: H5.1R R5.2
NetH5_3G: H5.3G R29.2
NetH6_1R: H6.1R R6.2
NetH6_3G: H6.3G R30.2
NetH7_1R: H7.1R R7.2
NetH7_3G: H7.3G R31.2
NetH8_1R: H8.1R R8.2
NetH8_3G: H8.3G R32.2
NetH9_1R: H9.1R R9.2
NetH9_3G: H9.3G R33.2
NetH10_1R: H10.1R R10.2
NetH10_3G: H10.3G R34.2
NetH11_1R: H11.1R R11.2
NetH11_3G: H11.3G R35.2
NetH12_1R: H12.1R R12.2
NetH12_3G: H12.3G R36.2
NetH13_1R: H13.1R R13.2
NetH13_3G: H13.3G R37.2
NetH14_1R: H14.1R R14.2
NetH14_3G: H14.3G R38.2
NetH15_1R: H15.1R R15.2
NetH15_3G: H15.3G R39.2
NetH16_1R: H16.1R R16.2
NetH16_3G: H16.3G R40.2
NetH17_1R: H17.1R R17.2
NetH17_3G: H17.3G R41.2
NetH18_1R: H18.1R R18.2
NetH18_3G: H18.3G R42.2
NetH19_1R: H19.1R R19.2
NetH19_3G: H19.3G R43.2
NetH20_1R: H20.1R R20.2
NetH20_3G: H20.3G R44.2
NetH21_1R: H21.1R R21.2
NetH21_3G: H21.3G R45.2
NetH22_1R: H22.1R R22.2
NetH22_3G: H22.3G R46.2
NetH23_1R: H23.1R R23.2
NetH23_3G: H23.3G R47.2
NetH24_1R: H24.1R R24.2
NetH24_3G: H24.3G R48.2
NetH25_1R: H25.1R R25.2
NetH25_3G: H25.3G R49.2
NetH26_1R: H26.1R R26.2
NetH26_3G: H26.3G R50.2
NetH27_1R: H27.1R R27.2
NetH27_3G: H27.3G R51.2
NetH28_1R: H28.1R R52.2
NetH28_3G: H28.3G R76.2
NetH29_1R: H29.1R R53.2
NetH29_3G: H29.3G R77.2
NetH30_1R: H30.1R R54.2
NetH30_3G: H30.3G R78.2
NetH31_1R: H31.1R R55.2
NetH31_3G: H31.3G R79.2
NetH32_1R: H32.1R R56.2
NetH32_3G: H32.3G R80.2
NetH33_1R: H33.1R R57.2
NetH33_3G: H33.3G R81.2
NetH34_1R: H34.1R R58.2
NetH34_3G: H34.3G R82.2
NetH35_1R: H35.1R R59.2
NetH35_3G: H35.3G R83.2
NetH36_1R: H36.1R R60.2
NetH36_3G: H36.3G R84.2
NetH37_1R: H37.1R R61.2
NetH37_3G: H37.3G R85.2
NetH38_1R: H38.1R R62.2
NetH38_3G: H38.3G R86.2
NetH39_1R: H39.1R R63.2
NetH39_3G: H39.3G R87.2
NetH40_1R: H40.1R R64.2
NetH40_3G: H40.3G R88.2
NetH41_1R: H41.1R R65.2
NetH41_3G: H41.3G R89.2
NetH42_1R: H42.1R R66.2
NetH42_3G: H42.3G R90.2
NetH43_1R: H43.1R R67.2
NetH43_3G: H43.3G R91.2
NetH44_1R: H44.1R R68.2
NetH44_3G: H44.3G R92.2
NetH45_1R: H45.1R R69.2
NetH45_3G: H45.3G R93.2
NetH46_1R: H46.1R R70.2
NetH46_3G: H46.3G R94.2
NetH47_1R: H47.1R R71.2
NetH47_3G: H47.3G R95.2
NetH48_1R: H48.1R R72.2
NetH48_3G: H48.3G R96.2
NetH49_1R: H49.1R R73.2
NetH49_3G: H49.3G R97.2
NetH50_1R: H50.1R R74.2
NetH50_3G: H50.3G R98.2
NetH51_1R: H51.1R R75.2
NetH51_3G: H51.3G R99.2
NetR148_2: R148.2 R149.2 V1.1
N00153:

Not connected: D1 1(NC); D2 1(NC); D3 1(NC); D4 1(NC); D5 1(NC); D6 1(NC); D7 11(NC) 14(NC) 19(INTB); D8 11(NC) 14(NC) 19(INTB); D9 11(NC) 14(NC) 19(INTB); D10 11; X1 7 9

## Library data of the parts
C1 C3 C5 C7 C9 C11 C13 C15 C17 C19 C21 C23 C25 C27 C29 C31 C33 C35 C37 C39 C41 C43 C45 C47-C58 = CERCAP_0805: Конденсатор керамический; Type: К10-69в-Н90-25В-2012М-0,1 мкФ (+80;-20)%; Замена: CC0805KPX7R9BB104; Производитель: АО "Гириконд"; ТУ: АДПК.673511.004 ТУ; Component Kind: Standard; Library Name: Конденсаторы.SchLib
C2 C4 C6 C8 C10 C12 C14 C16 C18 C20 C22 C24 C26 C28 C30 C32 C34 C36 C38 C40 C42 C44 C46 = CERCAP_0805: Конденсатор керамический; Type: К10-69в-Н90-25В-2012М-0,1 мкФ (+80;-20)%; Value: 0.1; Замена: CC0805KPX7R9BB104; Производитель: АО "Гириконд"; ТУ: АДПК.673511.004 ТУ; Component Kind: Standard; Library Name: Конденсаторы.SchLib
D1-D6 = TLC59116F: Драйвер светодиодов; Component Kind: Standard; Library Name: Микросхемы.SchLib
D7-D9 = MCP23017: Расширитель порта; Component Kind: Standard; Library Name: Микросхемы.SchLib
D10 = ЭКФ1533ЛЛ1: 4 х 2ИЛИ ТТЛ; Аналог: SN74ALS32D; Производитель: ОАО "Интеграл"; ТУ: бК0.348.806-40 ТУ; Component Kind: Standard; Library Name: Микросхемы.SchLib
H1 H3 = TLHG4400: Светодиод выв. зел. 3мм; Component Kind: Standard; Library Name: Устройства индикации.SchLib
H2 = TLHR4400: Светодиод выв. кр. 3мм; Component Kind: Standard; Library Name: Устройства индикации.SchLib
H4-H51 = SSL-LX3059IGW-CA: Светодиод выв. красн./зел. 3мм; Component Kind: Standard; Library Name: Устройства индикации.SchLib
R1-R99 = RES_0805: Резистор SMD; Замена: YAGEO RC0805JR-07220RL; Производитель: АО "Ресурс"; ТУ: ШКАБ.434110.024 ТУ; Component Kind: Standard; Type: Р1-12-0,125-220 Ом±5%; Library Name: Резисторы.SchLib
R100-R147 = RES_0805: Резистор SMD; Замена: YAGEO RC0805JR-075K1L; Производитель: АО "Ресурс"; ТУ: ШКАБ.434110.024 ТУ; Component Kind: Standard; Type: Р1-12-0,125-5,1 кОм±5%; Library Name: Резисторы.SchLib
R148 = RES_0805: Резистор SMD; Замена: YAGEO RC0805JR-072KL; Производитель: АО "Ресурс"; ТУ: ШКАБ.434110.024 ТУ; Component Kind: Standard; Type: Р1-12-0,125-2 кОм±5%; Library Name: Резисторы.SchLib
R149 = RES_0805: Резистор SMD; Замена: YAGEO RC0805JR-071KL; Производитель: АО "Ресурс"; ТУ: ШКАБ.434110.024 ТУ; Component Kind: Standard; Type: Р1-12-0,125-1 кОм±5%; Library Name: Резисторы.SchLib
S1-S48 = 1273SHIM-160G-G: Кнопка тактовая; Component Kind: Standard; Library Name: Коммутационные изделия.SchLib
V1 = КТ229Г9: Транзистор NPN; Аналог: BC817-40.215; Производитель: ЗАО "Кремний Эл"; ТУ: АДКБ.432140.555 ТУ; Component Kind: Standard; Library Name: Транзисторы.SchLib
X1 = IDC-14MS: Вилка; Component Kind: Standard; Library Name: Соединители.SchLib
